# Altium schematic sheet USB_power.SchDoc
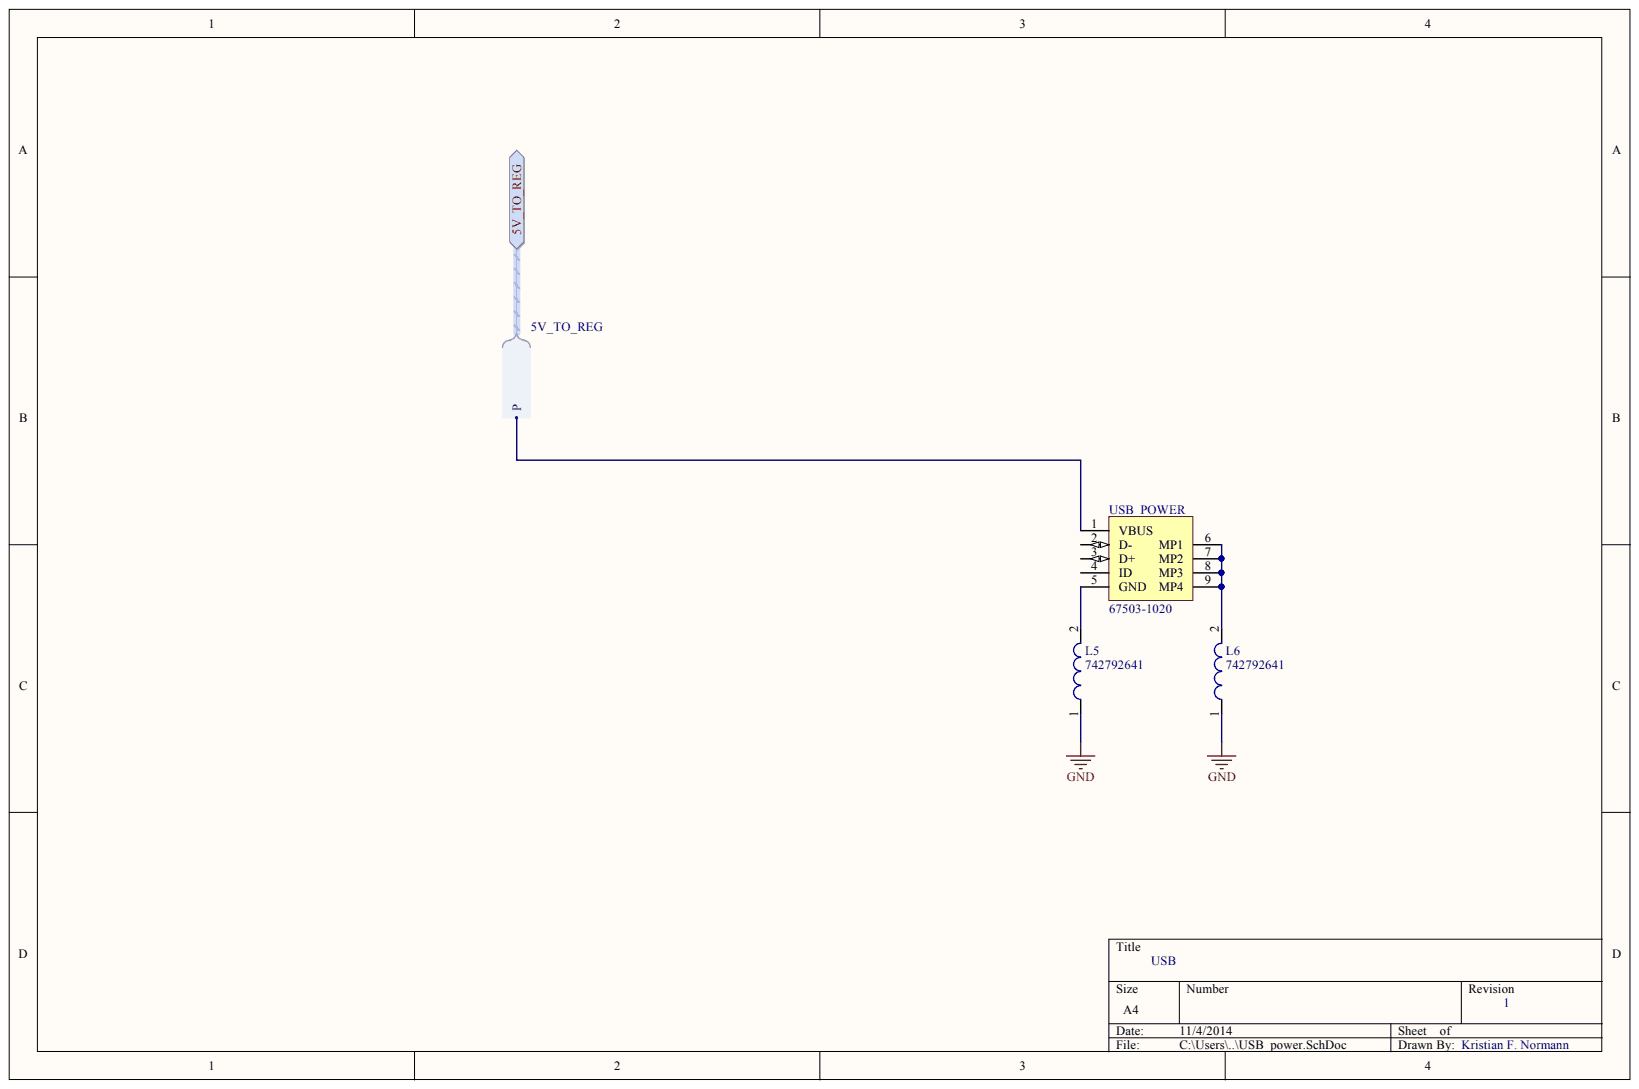
[diagram p0: USB_power.SchDoc]

## Parts
L5  742792641  [SMD-0603]
L6  742792641  [SMD-0603]
USB_POWER  67503-1020  [MOLX-67503-1020_V]

## Ports
5V_TO_REG, 5V_TO_REG.P

## Nets
GND: L5.1 L6.1
5V_TO_REG: USB_POWER.1(VBUS) ; port 5V_TO_REG
NetL5_2: L5.2 USB_POWER.5(GND)
NetL6_2: L6.2 USB_POWER.6(MP1) USB_POWER.7(MP2) USB_POWER.8(MP3) USB_POWER.9(MP4)

Not connected: USB_POWER 2(D-) 3(D+) 4(ID)

## Library data of the parts
L5 L6 = CMP-0220-00171-1: SMD EMI Suppression Ferrite Bead WE-CBF, Z = 300 Ohm; Impedance: 300 Ohm; Component Kind: Standard; ComponentLink1Description: Manufacturer Link; ComponentLink1URL: http://www.we-online.com; ComponentLink2Description: Datasheet; ComponentLink2URL: http://www.we-online.de/katalog/media/pdf/742792641.pdf; DatasheetVersion: Dec-2005; Library Name: Altium Content Vault; PackageDescription: Chip Inductor, 2-Leads, Body 1.6 x 0.8 mm; PackageReference: SMD-0603; PackageVersion: 2009
USB_POWER = CMP-0411-00002-1: USB, MINI, SMD, RA KME04-USBMU03A01; Manufacturer: Molex; Component Kind: Standard; ComponentLink1Description: Manufacturer URL; ComponentLink1URL: http://www.molex.com/; ComponentLink2Description: Datasheet; ComponentLink2URL: http://www.molex.com/pdm_docs/sd/675031020_sd.pdf; DatasheetVersion: Rev F, 06/2009; Library Name: Altium Content Vault; Mounting Technology: Surface Mount; PackageDescription: Mini USB Connector, SMT, Righer Angle; PackageReference: 67503-1020; PartNumber: 67503-1020; Terminal Pitch: 0.8 mm
